# Altium schematic sheet Photodiode_Circuit.SchDoc(Photodiode_Circuit)
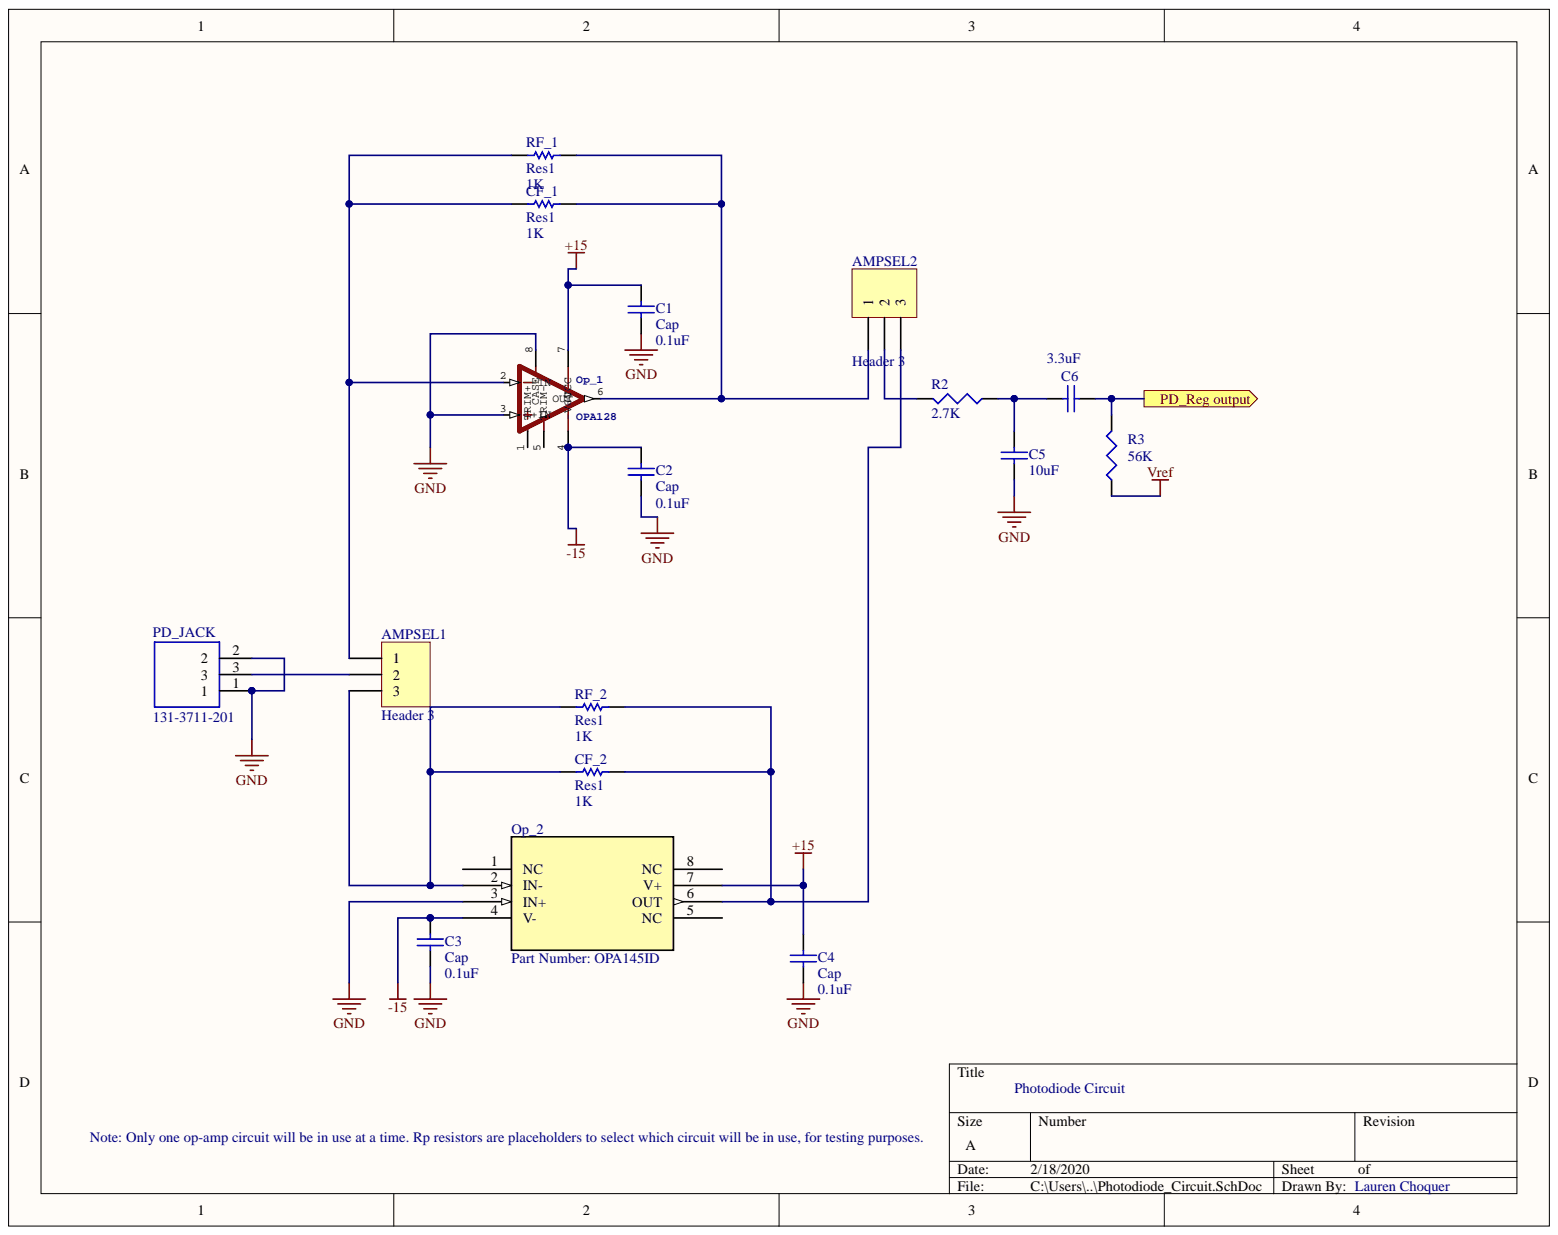
[diagram p0: Photodiode_Circuit.SchDoc]

## Parts
AMPSEL1  Header 3  [HDR1X3]
AMPSEL2  Header 3  [HDR1X3]
C1  Cap  value 0.1uF  [RAD-0.3]
C2  Cap  value 0.1uF  [RAD-0.3]
C3  Cap  value 0.1uF  [RAD-0.3]
C4  Cap  value 0.1uF  [RAD-0.3]
C5  value 10uF  [1608[0603]]  (hidden comment: Cap)
C6  value 3.3uF  [1608[0603]]  (hidden comment: Cap)
CF_1  Res1  value 1K  [AXIAL-0.3]
CF_2  Res1  value 1K  [AXIAL-0.3]
Op_1  OPA128  [TO-99]
Op_2  Part Number: OPA145ID  [D0008A_N]
PD_JACK  131-3711-201  [1313711201]
R2  value 2.7K  [J1-0603]  (hidden comment: Resistor)
R3  value 56K  [J1-0603]  (hidden comment: Resistor)
RF_1  Res1  value 1K  [AXIAL-0.3]
RF_2  Res1  value 1K  [AXIAL-0.3]

## Ports
PD_Reg output

## Nets
+15: C1.2 C4.2 Op_1.7(+VCC) Op_2.7(V+)
-15: C2.2 C3.2 Op_1.4(-VCC) Op_2.4(V-)
GND: C1.1 C2.1 C3.1 C4.1 C5.2 Op_1.3(+IN) Op_1.8(CASE) Op_2.3(IN+) PD_JACK.1 PD_JACK.2
Vref: R3.1
PD_Reg output: C6.1 R3.2 ; port PD_Reg output
NetAMPSEL1_1: AMPSEL1.1 CF_1.1 Op_1.2(-IN) RF_1.1
NetAMPSEL1_2: AMPSEL1.2 PD_JACK.3
NetAMPSEL1_3: AMPSEL1.3 CF_2.1 Op_2.2(IN-) RF_2.1
NetAMPSEL2_1: AMPSEL2.1 CF_1.2 Op_1.6(OUT) RF_1.2
NetAMPSEL2_2: AMPSEL2.2 R2.2
NetAMPSEL2_3: AMPSEL2.3 CF_2.2 Op_2.6(OUT) RF_2.2
NetC5_1: C5.1 C6.2 R2.1

Not connected: Op_1 1(TRIM+) 5(TRIM-); Op_2 1(NC) 5(NC) 8(NC)

## Library data of the parts
AMPSEL1 AMPSEL2 = Header 3: Header, 3-Pin; Signal Integrity: Connector; Library Name: Miscellaneous Connectors.IntLib; LatestRevisionNote: Re-released for DXP Platform.; LatestRevisionDate: 17-Jul-2002; Component Kind: Standard; Publisher: Altium Limited
C1-C6 = Cap: Capacitor; Simulation: CAP; Signal Integrity: Cap; Published: 8-Jun-2000; PackageReference: RAD-0.3; PackageDescription: Radial Cap, Thru-Hole; 2 Leads; 0.3 in Pin Spacing; Library Name: Miscellaneous Devices.IntLib; LatestRevisionNote: Re-released for DXP Platform.; LatestRevisionDate: 17-Jul-2002; Component Kind: Standard; Publisher: Altium Limited
CF_1 CF_2 = Res1: Resistor; Simulation: RESISTOR; Signal Integrity: Res; Published: 8-Jun-2000; PackageReference: AXIAL-0.3; PackageDescription: Axial Device, Thru-Hole; 2 Leads; 0.3 in Pin Spacing; Library Name: Miscellaneous Devices.IntLib; LatestRevisionNote: Re-released for DXP Platform.; LatestRevisionDate: 17-Jul-2002; Component Kind: Standard; Publisher: Altium Limited
Op_1 = OPA128: SymbolName_1: ELECTROMETER_OPAMP; GateName_1: U; Package: TO-99; DeviceSetName: OPA128; Component Kind: Standard; LibraryName: MSEG_converted; Library Name: MSEG_converted.IntLib
Op_2 = OPA145ID: No Description Available; Vendor: Texas Instruments; Built_By: EMA_UL_Team; Value: Value; Type: DEV; RefDes: RefDes; Manufacturer_Part_Number: OPA145ID; Library Name: 2020-01-02_22-59-40.SchLib; Component Kind: Standard; Copyright: Copyright (C) 2018 Accelerated Designs. All rights reserved
PD_JACK = 131-3711-201: Straight SMB Connector 50Ohms Gold/Brass; Manufacturer_Name: Cinch; Geometry.Height: 8.3312mm; Allied_Number: 70090138; RS Price/Stock: https://uk.rs-online.com/web/p/products/8860734; RS Part Number: 8860734; Mouser Price/Stock: https://www.mouser.com/Search/Refine.aspx?Keyword=530-131-3711-201; Mouser Part Number: 530-131-3711-201; Manufacturer_Part_Number: 131-3711-201; Library Name: 131-3711-201.SchLib; Datasheet Link: http://www.belfuse.com/resources/Johnson/drawings/dr-1313711201.pdf; Component Kind: Standard; Allied Price/Stock: https://www.alliedelec.com/johnson-cinchconnectivitysolutions-131-3711-201/70090138/
R2 R3 = Res3: Simulation: RESISTOR; Signal Integrity: Res; Published: 8-Jun-2000; PackageReference: J1-0603; PackageDescription: Chip Resistor; Library Name: Miscellaneous Devices.IntLib; LatestRevisionNote: IPC-7351 Footprint Added.; LatestRevisionDate: 29-May-2009; Description: Resistor; Component Kind: Standard; Publisher: Altium Limited
RF_1 RF_2 = Res1: Resistor; Value: 1K; Simulation: RESISTOR; Signal Integrity: Res; Published: 8-Jun-2000; PackageReference: AXIAL-0.3; PackageDescription: Axial Device, Thru-Hole; 2 Leads; 0.3 in Pin Spacing; Library Name: Miscellaneous Devices.IntLib; LatestRevisionNote: Re-released for DXP Platform.; LatestRevisionDate: 17-Jul-2002; Component Kind: Standard; Publisher: Altium Limited
